# Altium schematic sheet 4-Power.SchDoc(4-Power)
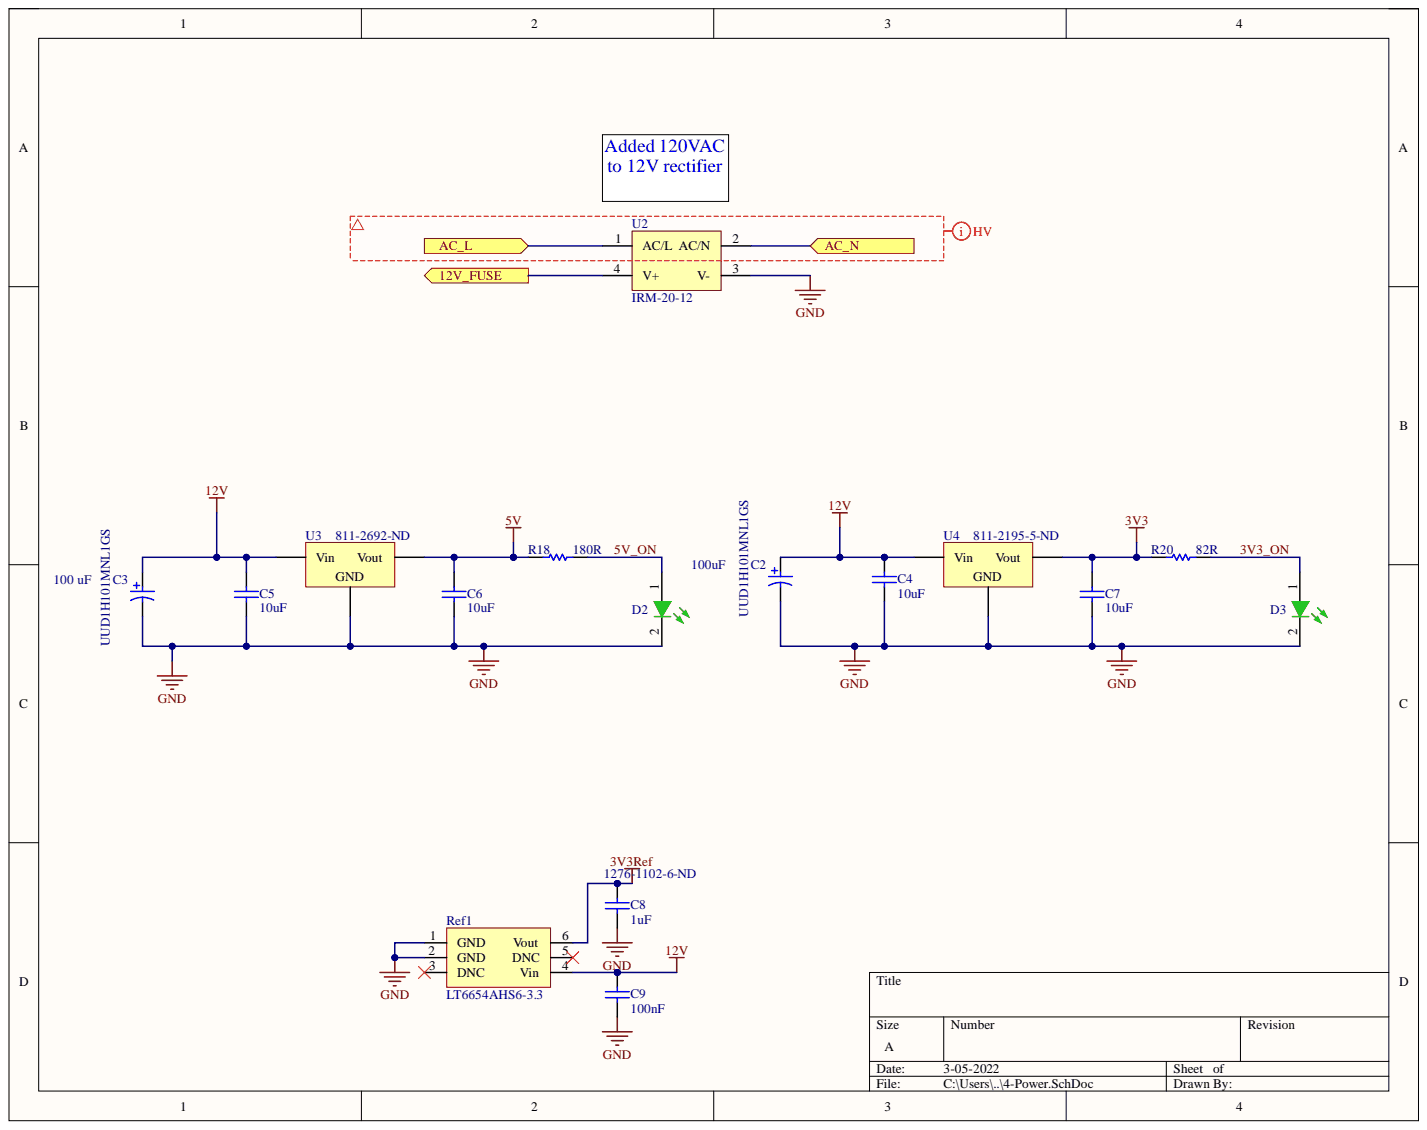
[diagram p0: 4-Power.SchDoc]

## Parts
C2  UUD1H101MNL1GS  [NIC-CAPA2-8X10_V]
C3  UUD1H101MNL1GS  [NIC-CAPA2-8X10_V]
C4  value 10uF  [C1210_M]  (hidden comment: Cap)
C5  value 10uF  [C1210_M]  (hidden comment: Cap)
C6  value 10uF  [C1210_M]  (hidden comment: Cap)
C7  value 10uF  [C1210_M]  (hidden comment: Cap)
C8  value 1uF  Supplier Part Number 1: 1276-1102-6-ND  [1608[0603]]  (hidden comment: Cap)
C9  value 100nF  [1608[0603]]  (hidden comment: Cap)
D2  KP-1608MGC  [KING-0603LED_GREEN_V]  (value not drawn)
D3  KP-1608MGC  [KING-0603LED_GREEN_V]  (value not drawn)
R18  value 180R  [1608[0603]]  (hidden comment: Res1)
R20  value 82R  [1608[0603]]  (hidden comment: Res1)
Ref1  LT6654AHS6-3.3  [TSOT-23]
U2  IRM-20-12  [MEANW-IRM-20-12]
U3  Supplier Part Number 1: 811-2692-ND  [DCDC_HORIZONTAL]  (hidden comment: Volt Reg)
U4  Supplier Part Number 1: 811-2195-5-ND  [DCDC_VERTICAL]  (hidden comment: Volt Reg)

## Ports
12V_FUSE, AC_L, AC_N

## Nets
3V3: C7.2 R20.1 U4.3
3V3Ref: C8.2 Ref1.6(Vout)
5V: C6.2 R18.1 U3.3
12V: C2.1 C3.1 C4.2 C5.2 C9.2 Ref1.4(Vin) U3.1 U4.1
GND: C2.2 C3.2 C4.1 C5.1 C6.1 C7.1 C8.1 C9.1 D2.2 D3.2 Ref1.1(GND) Ref1.2(GND) U2.3(V-) U3.2 U4.2
3V3_ON: D3.1 R20.2
5V_ON: D2.1 R18.2
12V_FUSE: U2.4(V+) ; port 12V_FUSE
AC_L: U2.1(AC/L) ; port AC_L
AC_N: U2.2(AC/N) ; port AC_N

Not connected: Ref1 3(DNC) 5(DNC)

## Library data of the parts
C2 C3 = CMP-0687-00073-1: Aluminum Electrolytic Capacitor, UD Series, Low Impedance, 100 uF, 50 V, + / - 20%, -55 to 105 degC, Chip Type, 8 x 10 mm D x L, Pb-Free, Reel; Manufacturer: Nichicon; Capacitance: 100µF; Endurance Time: 5000 h; Impedance: 0.34 Ohm; Lifetime @ Temp.: 5000 Hrs @ 105°C; Packing: Dia382mm Reel; Polarization: Polar; Rated Capacitance: 100 uF; Rated Ripple Current (at 100kHz): 300 mA; Rated Voltage: 50 V; Ripple Current: 300mA; Surface Mount Land Size: 0.327" L x 0.327" W (8.30mm x 8.30mm); Tolerance Rated Capacitance: -20 to 20%; Voltage Rating: 50V; Applications: General Purpose; Category: Capacitors; Component Kind: Standard; ComponentLink1Description: Manufacturer URL; ComponentLink1URL: http://www.nichicon.co.jp/; ComponentLink2Description: Datasheet; ComponentLink2URL: http://products.nichicon.co.jp/en/pdf/XJA043/e-ud.pdf; ComponentLink3Description: Package Specification; ComponentLink3URL: http://products.nichicon.co.jp/en/pdf/XJA043/e-ud.pdf; ComponentLink4Description: http://nichicon-us.com/english/products/pdfs/e-uud.pdf; ComponentLink4URL: http://nichicon-us.com/english/products/pdfs/e-uud.pdf; DatasheetVersion: 08/2012; Diameter: 8 mm; ESR (Equivalent Series Resistance): -; Height - Seated (Max): 0.394" (10.00mm); Lead Spacing: -; Length: 10 mm; Library Name: Altium Content Vault; Manufacturer Part Number: UUD1H101MNL1GS; Mounting Technology: Surface Mount; Mounting Type: Surface Mount; Operating Temperature: -55°C ~ 105°C; Package / Case: Radial, Can - SMD; PackageDescription: 2-Pin Surface Mount Device, Diameter 8 mm, Height 10 mm, Pitch 6.5 mm; PackageReference: CAPAE-D8H10; Packaging: Cut Tape (CT); Part Status: Active; PartNumber: UUD1H101MNL1GS; Pricing 1: 1=0.92, 10=0.685, 25=0.5868, 50=0.5478, 100=0.4696, 250=0.43044 (CAD); RoHS: TRUE; Size / Dimension: 0.315" Dia (8.00mm); Stock 1: 65948; Supplier 1: Digi-Key; Supplier Part Number 1: 493-2306-1-ND; Tolerance: ±20%
C4-C7 = Cap: Capacitor; Manufacturer: Yageo; Manufacturer Part Number: CC1210ZKY5V8BB106; Capacitance: 10µF; Temperature Coefficient: Y5V (F); Tolerance: -20%, +80%; Voltage - Rated: 25V; Applications: General Purpose; Category: Capacitors; Component Kind: Standard; ComponentLink1Description: http://www.yageo.com/documents/recent/UPY-GPHC_Y5V_6.3V-to-50V_7.pdf; ComponentLink1URL: http://www.yageo.com/documents/recent/UPY-GPHC_Y5V_6.3V-to-50V_7.pdf; Failure Rate: -; Features: -; Height - Seated (Max): -; Lead Spacing: -; Lead Style: -; Library Name: Miscellaneous Devices.IntLib; Mounting Type: Surface Mount, MLCC; Operating Temperature: -30°C ~ 85°C; Package / Case: 1210 (3225 Metric); Packaging: Cut Tape (CT); Part Status: Active; Pricing 1: 1=0.47, 10=0.323, 25=0.258, 50=0.2154, 100=0.1829, 250=0.14528, 500=0.12912, 1000=0.11298 (CAD); Ratings: -; RoHS: RoHS Compliant; Signal Integrity: Cap; Simulation: CAP; Size / Dimension: 0.126" L x 0.098" W (3.20mm x 2.50mm); Stock 1: 1156; Supplier 1: Digi-Key; Supplier Part Number 1: 311-2063-1-ND; Thickness (Max): 0.083" (2.10mm)
C8 = Cap: Capacitor; Manufacturer: Murata Electronics North America; Manufacturer Part Number: GRM188R61E105KA12D; Capacitance: 1µF; Temperature Coefficient: X5R; Tolerance: ±10%; Voltage - Rated: 25V; Applications: General Purpose; Category: Capacitors; Component Kind: Standard; ComponentLink1Description: http://www.murata.com/~/media/webrenewal/support/library/catalog/products/capacitor/mlcc/c02e.pdf; ComponentLink1URL: http://www.murata.com/~/media/webrenewal/support/library/catalog/products/capacitor/mlcc/c02e.pdf; Features: -; Height - Seated (Max): -; Lead Spacing: -; Lead Style: -; Library Name: Miscellaneous Devices.IntLib; Mounting Type: Surface Mount, MLCC; Operating Temperature: -55°C ~ 85°C; Package / Case: 0603 (1608 Metric); Packaging: Cut Tape (CT); Part Status: Active; Ratings: -; RoHS: RoHS Compliant; Signal Integrity: Cap; Simulation: CAP; Size / Dimension: 0.063" L x 0.031" W (1.60mm x 0.80mm); Supplier 1: Digi-Key; Thickness (Max): 0.035" (0.90mm)
C9 = Cap: Capacitor; Manufacturer: Yageo; Manufacturer Part Number: CC0603KRX7R9BB104; Capacitance: 0.10µF; Temperature Coefficient: X7R; Tolerance: ±10%; Voltage - Rated: 50V; Applications: General Purpose; Category: Capacitors; Component Kind: Standard; ComponentLink1Description: http://www.yageo.com/documents/recent/UPY-GPHC_X7R_6.3V-to-50V_16.pdf; ComponentLink1URL: http://www.yageo.com/documents/recent/UPY-GPHC_X7R_6.3V-to-50V_16.pdf; Failure Rate: -; Features: -; Height - Seated (Max): -; Lead Spacing: -; Lead Style: -; Library Name: Miscellaneous Devices.IntLib; Mounting Type: Surface Mount, MLCC; Operating Temperature: -55°C ~ 125°C; Package / Case: 0603 (1608 Metric); Packaging: Cut Tape (CT); Part Status: Active; Pricing 1: 1=0.15, 10=0.043, 25=0.0312, 50=0.0232, 100=0.0189, 250=0.0158, 500=0.01346, 1000=0.01059 (CAD); Ratings: -; RoHS: RoHS Compliant; Signal Integrity: Cap; Simulation: CAP; Size / Dimension: 0.063" L x 0.031" W (1.60mm x 0.80mm); Stock 1: 4016262; Supplier 1: Digi-Key; Supplier Part Number 1: 311-1344-1-ND; Thickness (Max): 0.035" (0.90mm)
D2 D3 = CMP-0404-00001-1: LED, SMT, 0603(1608), 1.1mm thickness, Green; Manufacturer: Kingbright; Forward Current: 30 mA; Packing Type: 2000 pcs / reel; Power Dissipation: 75 mW; Reverse Voltage: 5 V; Component Kind: Standard; ComponentLink1Description: Manufacturer URL; ComponentLink1URL: http://www.kingbright.com/; Library Name: Altium Content Vault; Mounting Technology: Surface Mount; PackageDescription: 2-Pin SMT LED, 1.6 x 0.8 mm Pitch; PackageReference: 0603LED_GREEN; PartNumber: KP-1608MGC; Storage Temperature: -40 to +85 degC; Supplier 1: Digi-Key; Supplier Part Number 1: 732-4980-1-ND
R18 = Res1: Resistor; Manufacturer: Yageo; Manufacturer Part Number: RC0603JR-07180RL; Power (Watts): 0.1W, 1/10W; Resistance (Ohms): 180; Temperature Coefficient: ±100ppm/°C; Tolerance: ±5%; Category: Resistors; Component Kind: Standard; ComponentLink1Description: http://www.yageo.com/NewPortal/yageodocoutput?fileName=/pdf/R-Chip/PYu-RC_Group_51_RoHS_L_4.pdf; ComponentLink1URL: http://www.yageo.com/NewPortal/yageodocoutput?fileName=/pdf/R-Chip/PYu-RC_Group_51_RoHS_L_4.pdf; Composition: Thick Film; Failure Rate: -; Features: Moisture Resistant; Height - Seated (Max): 0.022" (0.55mm); Library Name: Miscellaneous Devices.IntLib; Number of Terminations: 2; Operating Temperature: -55°C ~ 155°C; Package / Case: 0603 (1608 Metric); Packaging: Cut Tape (CT); Part Status: Active; Pricing 1: 1=0.16, 10=0.016, 25=0.0112, 50=0.0084, 100=0.0062, 250=0.0048, 500=0.00382, 1000=0.00281 (CAD); RoHS: RoHS Compliant; Signal Integrity: Res; Simulation: RESISTOR; Size / Dimension: 0.063" L x 0.031" W (1.60mm x 0.80mm); Stock 1: 201936; Supplier 1: Digi-Key; Supplier Device Package: 0603; Supplier Part Number 1: 311-180GRCT-ND
R20 = Res1: Resistor; Manufacturer: Yageo; Manufacturer Part Number: RC0603JR-0782RL; Power (Watts): 0.1W, 1/10W; Resistance (Ohms): 82; Temperature Coefficient: ±100ppm/°C; Tolerance: ±5%; Category: Resistors; Component Kind: Standard; ComponentLink1Description: http://www.yageo.com/NewPortal/yageodocoutput?fileName=/pdf/R-Chip/PYu-RC_Group_51_RoHS_L_4.pdf; ComponentLink1URL: http://www.yageo.com/NewPortal/yageodocoutput?fileName=/pdf/R-Chip/PYu-RC_Group_51_RoHS_L_4.pdf; Composition: Thick Film; Failure Rate: -; Features: Moisture Resistant; Height - Seated (Max): 0.022" (0.55mm); Library Name: Miscellaneous Devices.IntLib; Number of Terminations: 2; Operating Temperature: -55°C ~ 155°C; Package / Case: 0603 (1608 Metric); Packaging: Cut Tape (CT); Part Status: Active; Pricing 1: 1=0.16, 10=0.016, 25=0.0112, 50=0.0084, 100=0.0062, 250=0.0048, 500=0.00382, 1000=0.00281 (CAD); RoHS: RoHS Compliant; Signal Integrity: Res; Simulation: RESISTOR; Size / Dimension: 0.063" L x 0.031" W (1.60mm x 0.80mm); Stock 1: 72049; Supplier 1: Digi-Key; Supplier Device Package: 0603; Supplier Part Number 1: 311-82GRCT-ND
Ref1 = LT6654AHS6-3.3: TSOT-23-56; Manufacturer: Linear Technology; Current - Output: 10mA; Current - Supply: 600µA; Noise - 0.1Hz to 10Hz: 1.7ppmp-p; Noise - 10Hz to 10kHz: 2ppmrms; Output Type: Fixed; Reference Type: Series; Temperature Coefficient: 10ppm/°C; Tolerance: ±0.05%; Voltage - Input: 3.8 V ~ 36 V; Voltage - Output (Min/Fixed): 3.3V; Category: Integrated Circuits (ICs); Component Kind: Standard; ComponentLink1Description: http://www.linear.com/docs/29677; ComponentLink1URL: http://www.linear.com/docs/29677; Current - Cathode: -; Digikey Link: http://www.digikey.com/product-detail/en/linear-technology/LT6654AHS6-3.3-TRMPBF/LT6654AHS6-3.3-TRMPBFCT-ND/2485839; Digikey Part Number: LT6654AHS6-3.3#TRMPBFCT-ND; Manufacturer Part Number: LT6654AHS6-3.3; Mounting Type: Surface Mount; Operating Temperature: -40°C ~ 125°C (TA); Package / Case: SOT-23-6 Thin, TSOT-23-6; Packaging: Cut Tape (CT); Part Status: Active; Pricing 1: 1=9.53, 25=8.508, 100=5.5596, 250=5.1048 (CAD); RoHS: RoHS Compliant; Stock 1: 1315; Supplier 1: Digi-Key; Supplier Device Package: TSOT-23-6; Supplier Part Number 1: LT6654AHS6-3.3#TRMPBFCT-ND; Voltage - Output (Max): -; Library Name: VCU Library.SchLib
U2 = CMP-2000-07460-1: Single Output Encapsulated Type, 12 V, 1.8 A, 21.6 W, -40 to 85 degC, 4-Pin THD, RoHS; Manufacturer: Mean Well; Approvals: CB; Current - Output 1: 1.8A; Efficiency: 84%; Max Output Power: 21.6W; Number of Outputs: 1; Voltage - Output 1: 12V; Component Kind: Standard; Datasheet URL: http://datasheet.octopart.com/IRM-20-12-Mean-Well-datasheet-42021592.pdf; Datasheet Version: 06/2015; Height: 24mm; Lead Free: LeadFree; Length: 53mm; Library Name: Altium Content Vault; Manufacturer URL: http://www.meanwellusa.com/; Max Operating Temperature: 70degC; Min Operating Temperature: -30degC; Mount: ThroughHole; Mounting Technology: ThroughHole; Number of Pins: 4; Output Current: 1.8A; Output Power: 21.6W; Package Description: 4-Pin Through Hole Device, Body 52.4 x 27.2 mm, Height 24 mm; Package Quantity: 240; Package Reference: IRM-20-12-4; Packaging: Bulk; RoHSCompliant: true; Supplier 1: Digi-Key; Supplier Part Number 1: 1866-3038-ND; Weight: 2.081164oz; Width: 28mm
U3 U4 = Volt Reg: Voltage Regulator; Component Kind: Standard; LatestRevisionDate: 29-May-2009; LatestRevisionNote: IPC-7351 Footprint Added.; Library Name: Miscellaneous Devices.IntLib; PackageDescription: 3-Pin Transistor Outline Package 2.54 mm Pitch, 15.1 mm Lead Span; PackageReference: D2PAK; Published: 24-Mar-1999; Supplier 1: Digi-Key; Publisher: Altium Limited
